# Altium schematic sheet Schematic.SchDoc
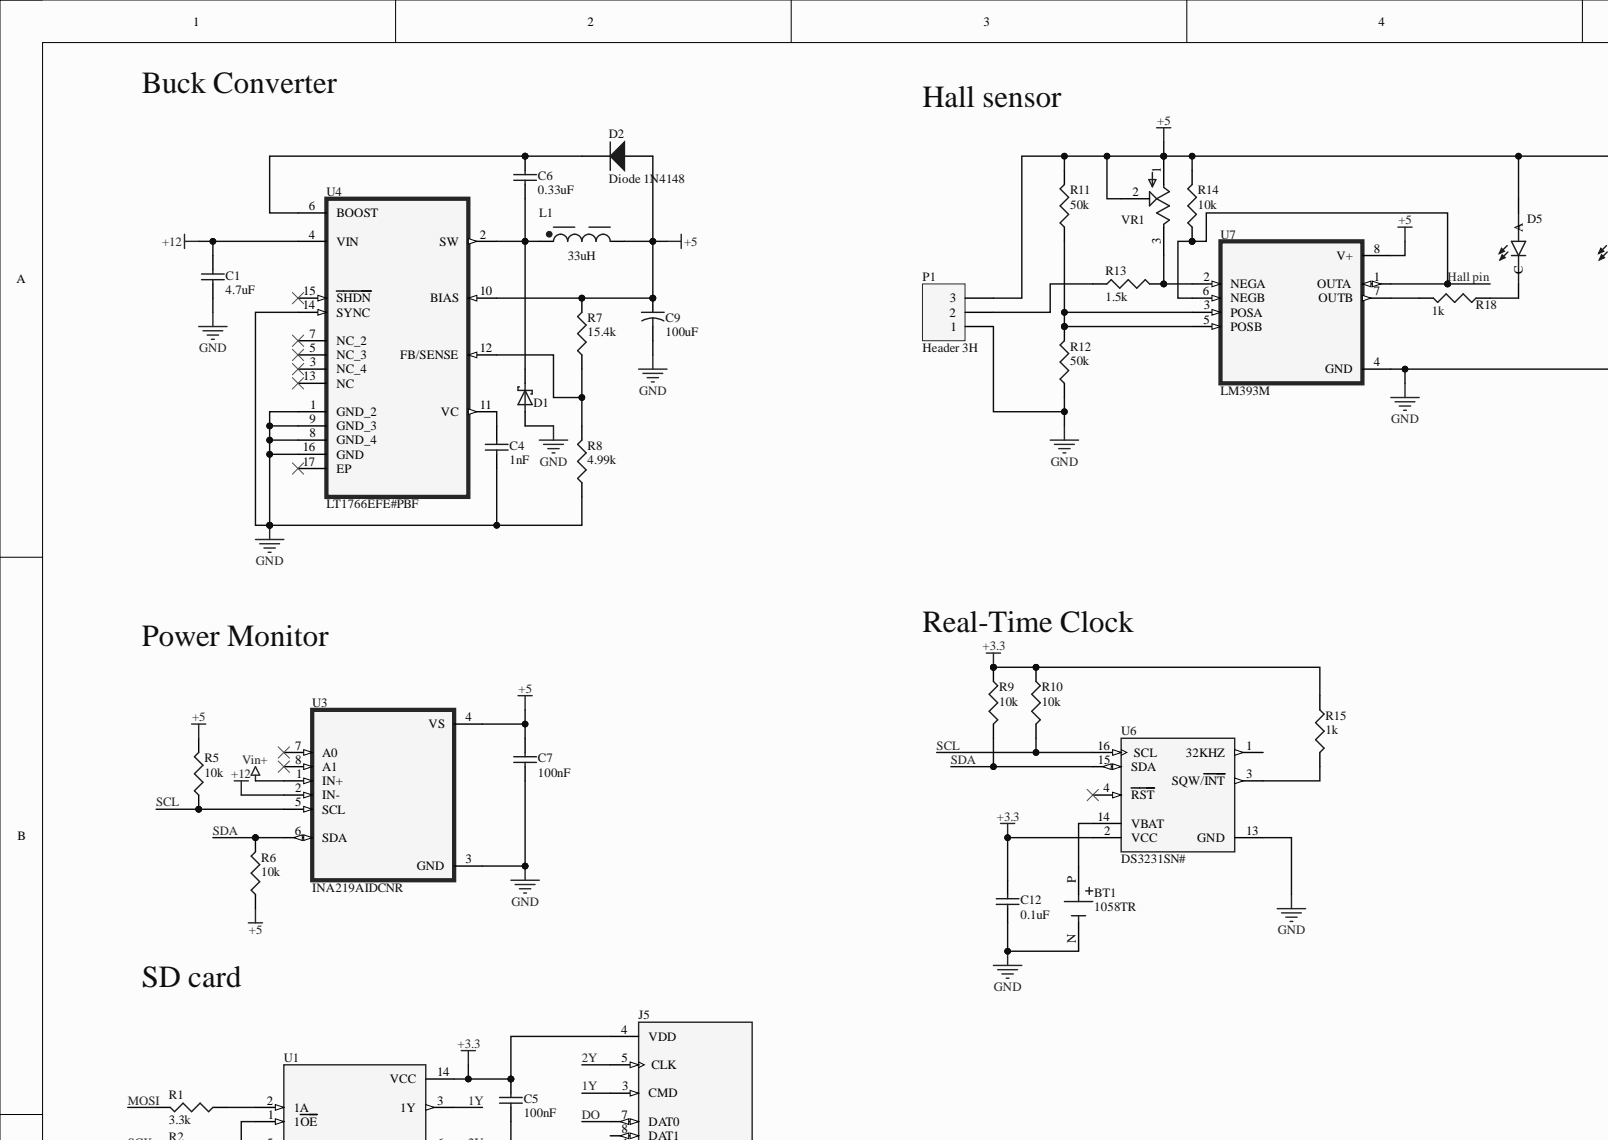
[diagram p0: Schematic.SchDoc, top left]
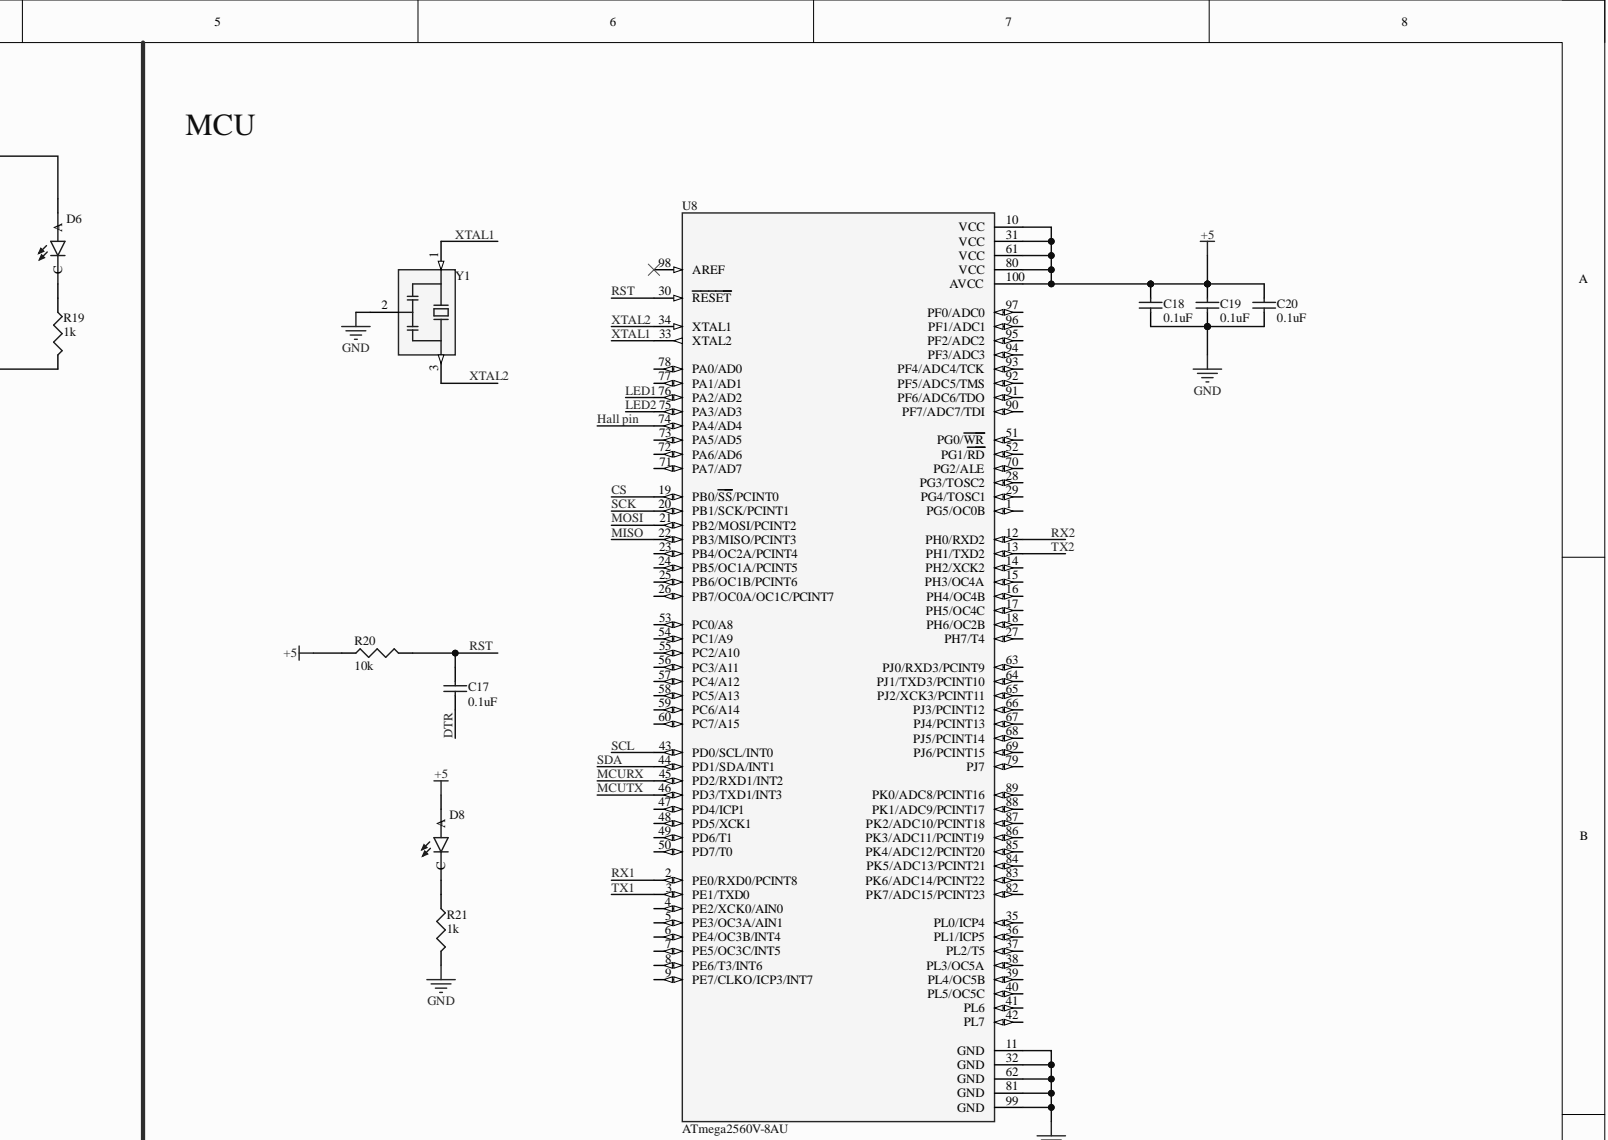
[diagram p1: Schematic.SchDoc, top right]
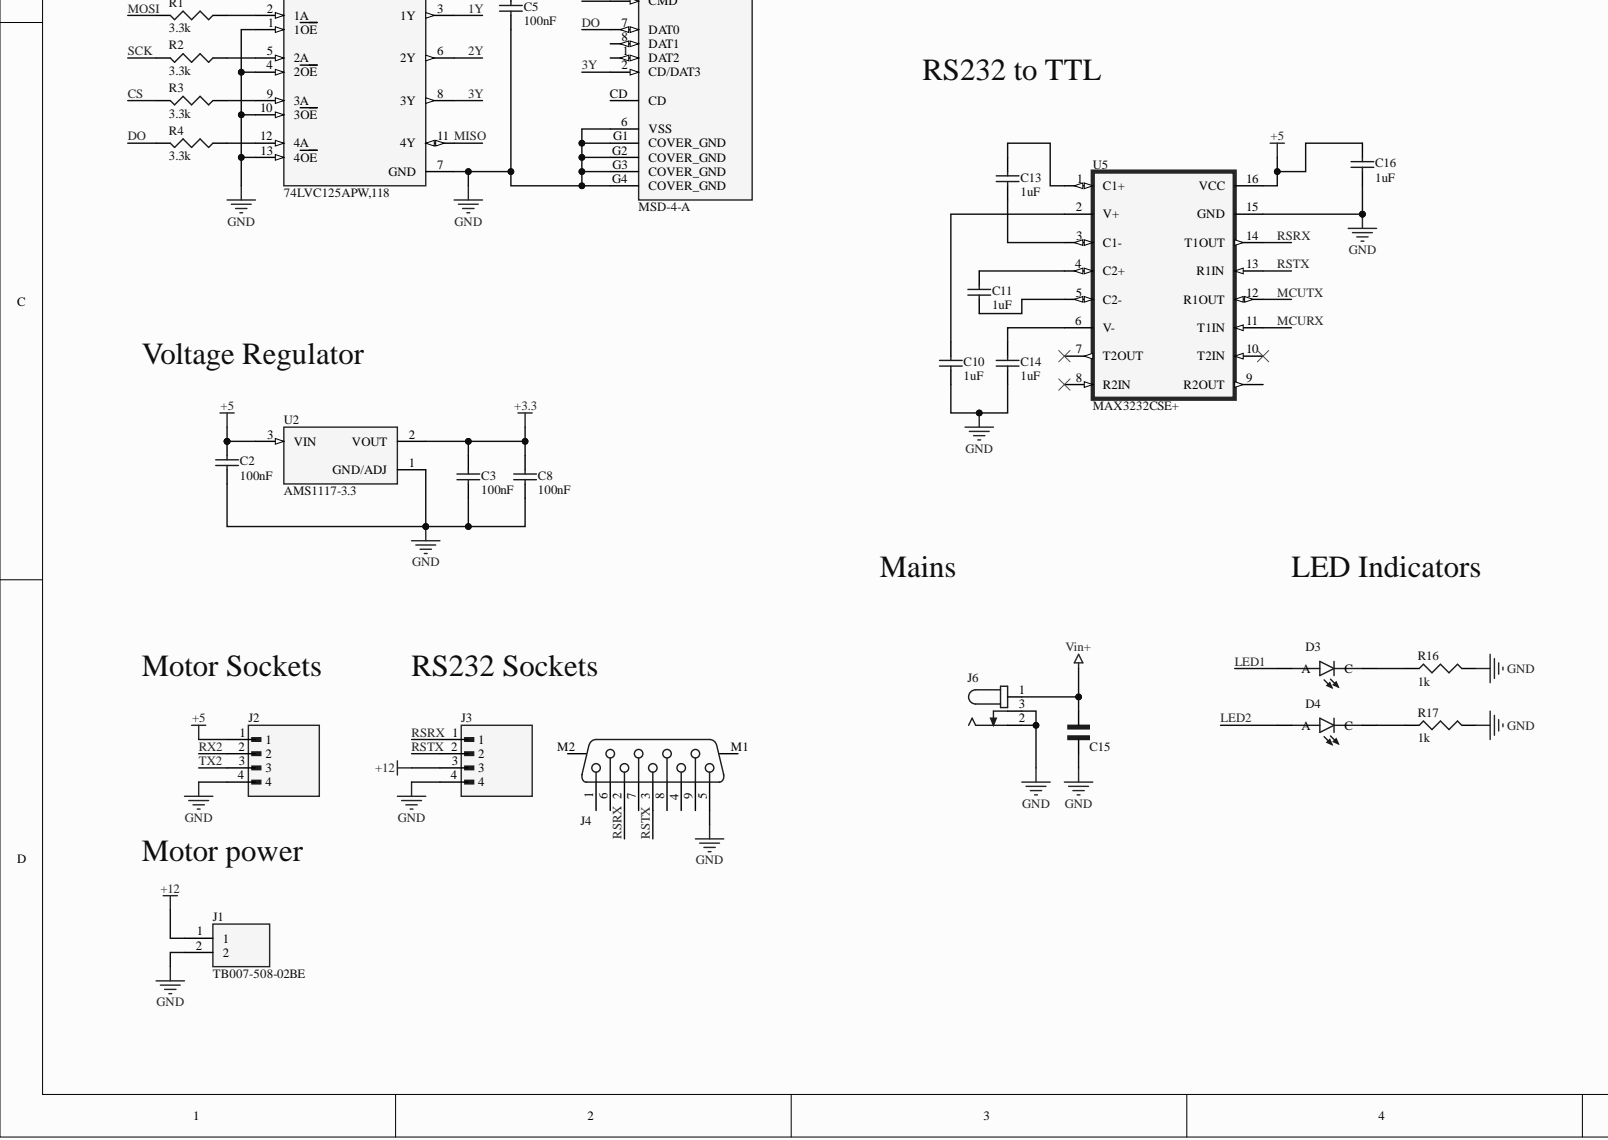
[diagram p2: Schematic.SchDoc, bottom left]
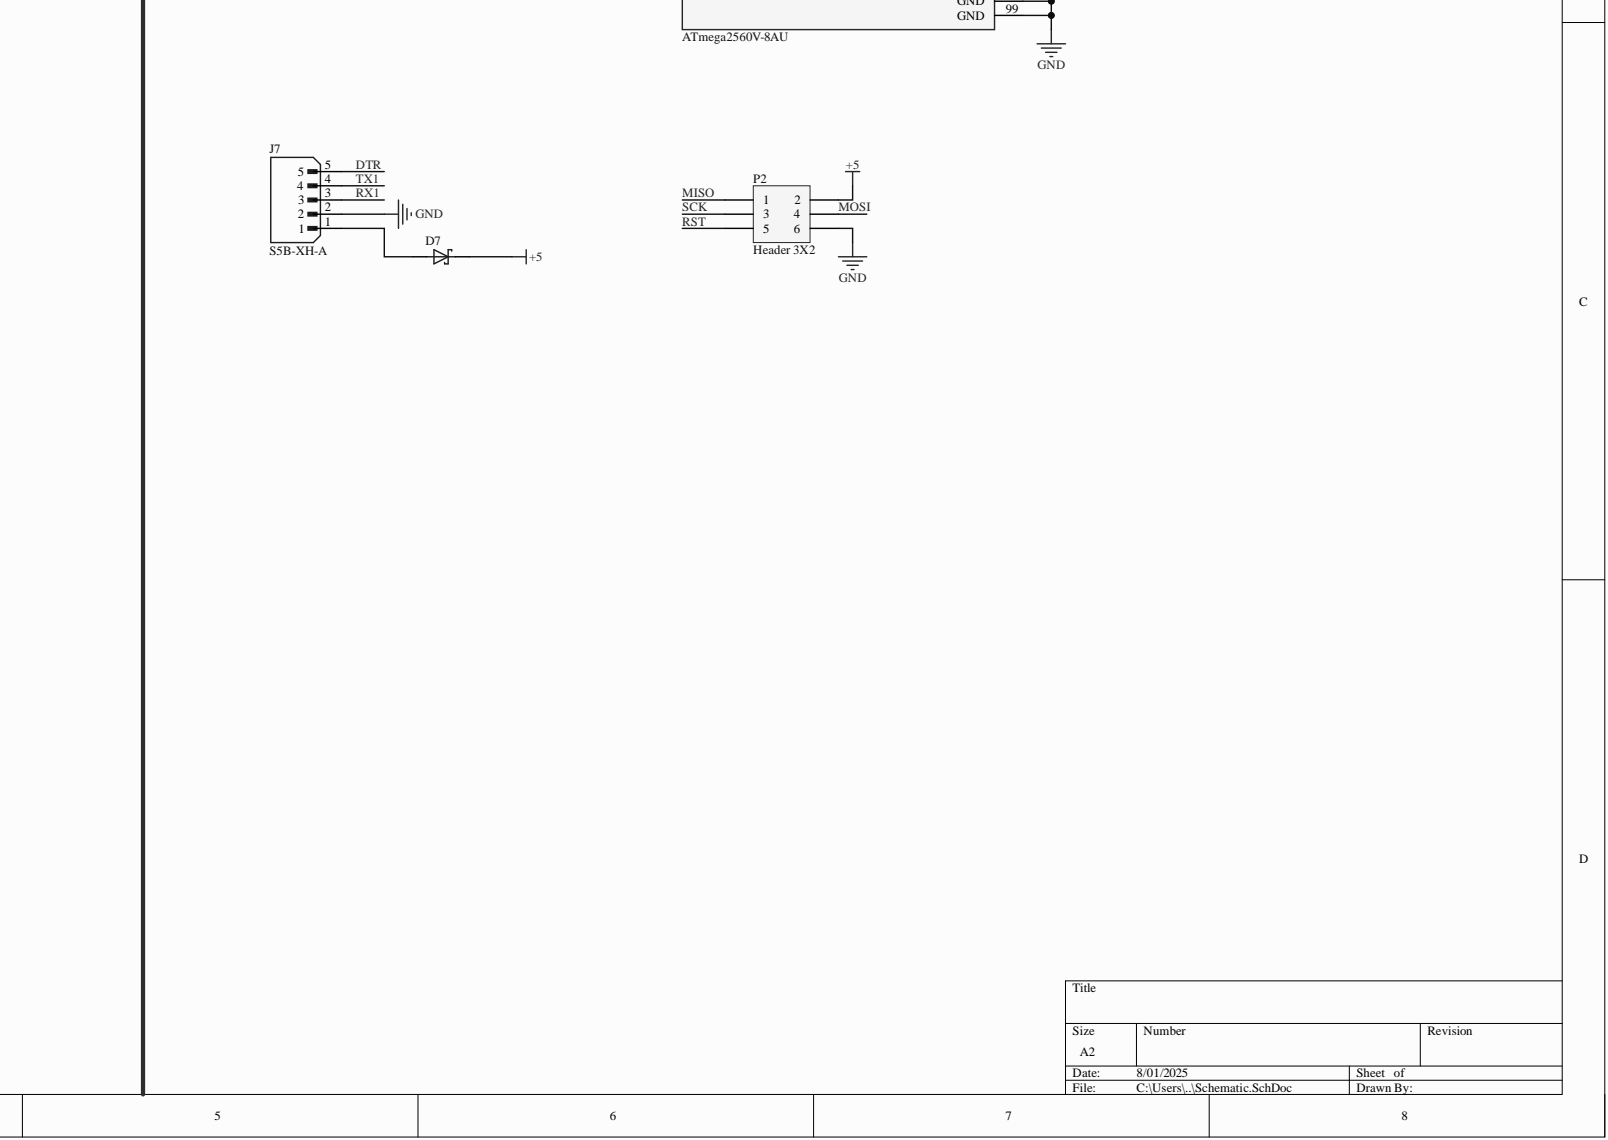
[diagram p3: Schematic.SchDoc, bottom right]

## Parts
BT1  1058TR  [BAT_1058TR]
C1  value 4.7uF  [INDC1608X95]  (hidden comment: Cap Semi)
C2  value 100nF  [INDC1608X95]  (hidden comment: Cap Semi)
C3  value 100nF  [INDC1608X95]  (hidden comment: Cap Semi)
C4  value 1nF  [INDC1608X95]  (hidden comment: Cap Semi)
C5  value 100nF  [INDC1608X95]  (hidden comment: Cap Semi)
C6  value 0.33uF  [INDC1608X95]  (hidden comment: Cap Semi)
C7  value 100nF  [INDC1608X95]  (hidden comment: Cap Semi)
C8  value 100nF  [INDC1608X95]  (hidden comment: Cap Semi)
C9  value 100uF  [CAPR5-4X5]  (hidden comment: Cap2)
C10  value 1uF  [INDC1608X95]  (hidden comment: Cap Semi)
C11  value 1uF  [INDC1608X95]  (hidden comment: Cap Semi)
C12  value 0.1uF  [INDC1608X95]  (hidden comment: Cap Semi)
C13  value 1uF  [INDC1608X95]  (hidden comment: Cap Semi)
C14  value 1uF  [INDC1608X95]  (hidden comment: Cap Semi)
C15  GRM21BC71E106KE11L  [CAPC2012X145N]  (value not drawn)
C16  value 1uF  [INDC1608X95]  (hidden comment: Cap Semi)
C17  value 0.1uF  [INDC1608X95]  (hidden comment: Cap Semi)
C18  value 0.1uF  [INDC1608X95]  (hidden comment: Cap Semi)
C19  value 0.1uF  [INDC1608X95]  (hidden comment: Cap Semi)
C20  value 0.1uF  [INDC1608X95]  (hidden comment: Cap Semi)
D1  B520CQ-13-F  [DIOM5226X240N]  (value not drawn)
D2  Diode 1N4148  [DO-35]
D3  597-3326-607F  [LEDSC160X80X70L45N]  (value not drawn)
D4  597-3326-607F  [LEDSC160X80X70L45N]  (value not drawn)
D5  597-3326-607F  [LEDSC160X80X70L45N]  (value not drawn)
D6  597-3326-607F  [LEDSC160X80X70L45N]  (value not drawn)
D7  B520CQ-13-F  [DIOM5226X240N]  (value not drawn)
D8  597-3326-607F  [LEDSC160X80X70L45N]  (value not drawn)
J1  TB007-508-02BE  [CUI_TB007-508-02BE]
J2  S4B-XH-A(LF)(SN)  [JST_S4B-XH-A(LF)(SN)]  (value not drawn)
J3  S4B-XH-A(LF)(SN)  [JST_S4B-XH-A(LF)(SN)]  (value not drawn)
J4  618009231121  [618009231121]  (value not drawn)
J5  MSD-4-A  [CUI_MSD-4-A]
J6  694103303002  [694103303002]  (value not drawn)
J7  S5B-XH-A  [JST_S5B-XH-A]
L1  33uH  [WE-PD HV_1280]
P1  Header 3H  [HDR1X3H]
P2  Header 3X2  [HDR2X3]
R1  value 3.3k  [J1-0603]  (hidden comment: Res3)
R2  value 3.3k  [J1-0603]  (hidden comment: Res3)
R3  value 3.3k  [J1-0603]  (hidden comment: Res3)
R4  value 3.3k  [J1-0603]  (hidden comment: Res3)
R5  value 10k  [J1-0603]  (hidden comment: Res3)
R6  value 10k  [J1-0603]  (hidden comment: Res3)
R7  value 15.4k  [J1-0603]  (hidden comment: Res3)
R8  value 4.99k  [J1-0603]  (hidden comment: Res3)
R9  value 10k  [J1-0603]  (hidden comment: Res3)
R10  value 10k  [J1-0603]  (hidden comment: Res3)
R11  value 50k  [J1-0603]  (hidden comment: Res3)
R12  value 50k  [J1-0603]  (hidden comment: Res3)
R13  value 1.5k  [J1-0603]  (hidden comment: Res3)
R14  value 10k  [J1-0603]  (hidden comment: Res3)
R15  value 1k  [J1-0603]  (hidden comment: Res3)
R16  value 1k  [J1-0603]  (hidden comment: Res3)
R17  value 1k  [J1-0603]  (hidden comment: Res3)
R18  value 1k  [J1-0603]  (hidden comment: Res3)
R19  value 1k  [J1-0603]  (hidden comment: Res3)
R20  value 10k  [J1-0603]  (hidden comment: Res3)
R21  value 1k  [J1-0603]  (hidden comment: Res3)
U1  74LVC125APW,118  [IC_74LVC125APW,118]
U2  AMS1117-3.3  [SOT229P700X180-4N]
U3  INA219AIDCNR  [SOT65P280X145-8N]
U4  LT1766EFE#PBF  [SOP65P640X110-17N]
U5  MAX3232CSE+  [SOIC127P600X175-16N]
U6  DS3231SN#  [SOIC127P1032X265-16N]
U7  LM393M  [SOIC127P599X175-8N]
U8  ATmega2560V-8AU  [QFP50P1600X1600X120-100N]
VR1  3362P-1-104  [TRIM_3362P-1-104]  (value not drawn)
Y1  CSTCE16M0V53-R0  [OSC_CSTCE16M0V53-R0]  (value not drawn)

## Nets
+3.3: C3.2 C5.2 C8.2 C12.2 J5.4(VDD) R9.1 R10.1 R15.1 U1.14(VCC) U2.2 U6.2(VCC)
+5: C2.2 C7.2 C9.1 C16.2 C18.1 C19.1 C20.1 D2.1 D5.A D6.A D7.C D8.A J2.1 L1.2 P1.3 P2.2 R5.2 R6.1 R7.2 R11.2 R14.2 R20.1 U2.3 U3.4(VS) U4.10(BIAS) U5.16(VCC) U7.8(V+) U8.10(VCC) U8.31(VCC) U8.61(VCC) U8.80(VCC) U8.100(AVCC) VR1.1 VR1.2
+12: C1.2 J1.1 J3.3 U3.2(IN-) U4.4(VIN)
GND: BT1.N C1.1 C2.1 C3.1 C4.1 C5.1 C7.1 C8.1 C9.2 C10.1 C12.1 C14.1 C15.1 C16.1 C18.2 C19.2 C20.2 D1.A J1.2 J2.4 J3.4 J4.5 J5.6(VSS) J5.G1(COVER_GND) J5.G2(COVER_GND) J5.G3(COVER_GND) J5.G4(COVER_GND) J6.2 J6.3 J7.2 P1.1 P2.6 R8.1 R12.1 R16.2 R17.2 R19.2 R21.2 U1.1(1OE) U1.4(2OE) U1.7(GND) U1.10(3OE) U1.13(4OE) U2.1 U3.3(GND) U4.1(GND_2) U4.8(GND_4) U4.9(GND_3) U4.14(SYNC) U4.16(GND) U5.15(GND) U6.13(GND) U7.4(GND) U8.11(GND) U8.32(GND) U8.62(GND) U8.81(GND) U8.99(GND) Y1.2
Vin+: C15.2 J6.1 U3.1(IN+)
1Y: J5.3(CMD) U1.3(1Y)
2Y: J5.5(CLK) U1.6(2Y)
3Y: J5.2(CD/DAT3) U1.8(3Y)
CS: R3.2 U8.19(PB0/SS/PCINT0)
DO: J5.7(DAT0) R4.2
DTR: C17.2 J7.5
Hall pin: R14.1 U7.1(OUTA) U7.6(NEGB) U8.74(PA4/AD4)
LED1: D3.A U8.76(PA2/AD2)
LED2: D4.A U8.75(PA3/AD3)
MCURX: U5.11(T1IN) U8.45(PD2/RXD1/INT2)
MCUTX: U5.12(R1OUT) U8.46(PD3/TXD1/INT3)
MISO: P2.1 U1.11(4Y) U8.22(PB3/MISO/PCINT3)
MOSI: P2.4 R1.2 U8.21(PB2/MOSI/PCINT2)
RSRX: J3.1 J4.2 U5.14(T1OUT)
RST: C17.1 P2.5 R20.2 U8.30(RESET)
RSTX: J3.2 J4.3 U5.13(R1IN)
RX1: J7.3 U8.2(PE0/RXD0/PCINT8)
RX2: J2.2 U8.12(PH0/RXD2)
SCK: P2.3 R2.2 U8.20(PB1/SCK/PCINT1)
SCL: R5.1 R10.2 U3.5(SCL) U6.16(SCL) U8.43(PD0/SCL/INT0)
SDA: R6.2 R9.2 U3.6(SDA) U6.15(SDA) U8.44(PD1/SDA/INT1)
TX1: J7.4 U8.3(PE1/TXD0)
TX2: J2.3 U8.13(PH1/TXD2)
XTAL1: U8.33(XTAL2) Y1.1
XTAL2: U8.34(XTAL1) Y1.3
NetBT1_P: BT1.P U6.14(VBAT)
NetC4_2: C4.2 U4.11(VC)
NetC6_1: C6.1 D1.C L1.1 U4.2(SW)
NetC6_2: C6.2 D2.2 U4.6(BOOST)
NetC10_2: C10.2 U5.2(V+)
NetC11_1: C11.1 U5.5(C2-)
NetC11_2: C11.2 U5.4(C2+)
NetC13_1: C13.1 U5.3(C1-)
NetC13_2: C13.2 U5.1(C1+)
NetC14_2: C14.2 U5.6(V-)
NetD3_C: D3.C R16.1
NetD4_C: D4.C R17.1
NetD5_C: D5.C R18.1
NetD6_C: D6.C R19.1
NetD7_A: D7.A J7.1
NetD8_C: D8.C R21.1
NetP1_2: P1.2 R13.1
NetR1_1: R1.1 U1.2(1A)
NetR2_1: R2.1 U1.5(2A)
NetR3_1: R3.1 U1.9(3A)
NetR4_1: R4.1 U1.12(4A)
NetR7_1: R7.1 R8.2 U4.12(FB/SENSE)
NetR11_1: R11.1 R12.2 U7.3(POSA) U7.5(POSB)
NetR13_2: R13.2 U7.2(NEGA) VR1.3
NetR15_2: R15.2 U6.3(SQW/INT)
NetR18_2: R18.2 U7.7(OUTB)

Not connected: J4 1 4 6 7 8 9 M1 M2; J5 1(DAT2) 8(DAT1) CD; U3 7(A0) 8(A1); U4 3(NC_4) 5(NC_3) 7(NC_2) 13(NC) 15(SHDN) 17(EP); U5 7(T2OUT) 8(R2IN) 9(R2OUT) 10(T2IN); U6 1(32KHZ) 4(RST); U8 1(PG5/OC0B) 4(PE2/XCK0/AIN0) 5(PE3/OC3A/AIN1) 6(PE4/OC3B/INT4) 7(PE5/OC3C/INT5) 8(PE6/T3/INT6) 9(PE7/CLKO/ICP3/INT7) 14(PH2/XCK2) 15(PH3/OC4A) 16(PH4/OC4B) 17(PH5/OC4C) 18(PH6/OC2B) 23(PB4/OC2A/PCINT4) 24(PB5/OC1A/PCINT5) 25(PB6/OC1B/PCINT6) 26(PB7/OC0A/OC1C/PCINT7) 27(PH7/T4) 28(PG3/TOSC2) 29(PG4/TOSC1) 35(PL0/ICP4) 36(PL1/ICP5) 37(PL2/T5) 38(PL3/OC5A) 39(PL4/OC5B) 40(PL5/OC5C) 41(PL6) 42(PL7) 47(PD4/ICP1) 48(PD5/XCK1) 49(PD6/T1) 50(PD7/T0) 51(PG0/WR) 52(PG1/RD) 53(PC0/A8) 54(PC1/A9) 55(PC2/A10) 56(PC3/A11) 57(PC4/A12) 58(PC5/A13) 59(PC6/A14) 60(PC7/A15) 63(PJ0/RXD3/PCINT9) 64(PJ1/TXD3/PCINT10) 65(PJ2/XCK3/PCINT11) 66(PJ3/PCINT12) 67(PJ4/PCINT13) 68(PJ5/PCINT14) 69(PJ6/PCINT15) 70(PG2/ALE) 71(PA7/AD7) 72(PA6/AD6) 73(PA5/AD5) 77(PA1/AD1) 78(PA0/AD0) 79(PJ7) 82(PK7/ADC15/PCINT23) 83(PK6/ADC14/PCINT22) 84(PK5/ADC13/PCINT21) 85(PK4/ADC12/PCINT20) 86(PK3/ADC11/PCINT19) 87(PK2/ADC10/PCINT18) 88(PK1/ADC9/PCINT17) 89(PK0/ADC8/PCINT16) 90(PF7/ADC7/TDI) 91(PF6/ADC6/TDO) 92(PF5/ADC5/TMS) 93(PF4/ADC4/TCK) 94(PF3/ADC3) 95(PF2/ADC2) 96(PF1/ADC1) 97(PF0/ADC0) 98(AREF)

## Library data of the parts
BT1 = 1058TR: SMT (Auto-In) Holder for 2025 & 2032 Cell on Tape & Reel | Keystone Electronics 1058TR; Check_prices: https://www.snapeda.com/parts/1058TR/Keystone/view-part/?ref=eda; Component Kind: Standard; Library Name: 1058TR.IntLib; MF: Keystone Electronics; MP: 1058TR; Package: None; SnapEDA_Link: https://www.snapeda.com/parts/1058TR/Keystone/view-part/?ref=snap
C1-C8 C10-C14 C16-C20 = Cap Semi: Capacitor (Semiconductor SIM Model); Component Kind: Standard; LatestRevisionDate: 29-May-2009; LatestRevisionNote: IPC-7351 Footprint Added.; Library Name: Miscellaneous Devices.IntLib; PackageDescription: Chip Capacitor; PackageReference: C1206; Published: 8-Jun-2000; Signal Integrity: Cap Semi; Simulation: CAP; Publisher: Altium Limited
C9 = Cap2: Capacitor; Component Kind: Standard; Library Name: Miscellaneous Devices.IntLib; PackageDescription: Capacitor; 2 Leads; PackageReference: CAPR5-4X5; Published: 23-Sep-2002; Signal Integrity: Cap; Simulation: CAP; Publisher: Altium Limited
C15 = GRM21BC71E106KE11L: SMD Capacitor X7S(EIA) with Capacitance: 10uF Tol. 10%. Rated Voltage: 25Vdc; MF: Murata; Check_prices: https://www.snapeda.com/parts/GRM21BC71E106KE11L/Murata/view-part/?ref=eda; Component Kind: Standard; Library Name: GRM21BC71E106KE11L.IntLib; MP: GRM21BC71E106KE11L; Package: 2012 Murata; SnapEDA_Link: https://www.snapeda.com/parts/GRM21BC71E106KE11L/Murata/view-part/?ref=snap
D1 D7 = B520CQ-13-F: Diode Schottky 20 V 5A Surface Mount SMC; MF: Diodes Inc.; Check_prices: https://www.snapeda.com/parts/B520CQ-13-F/Diodes+Inc./view-part/?ref=eda; Component Kind: Standard; Library Name: B520CQ-13-F.IntLib; MP: B520CQ-13-F; Package: SMC-2 Diodes; SnapEDA_Link: https://www.snapeda.com/parts/B520CQ-13-F/Diodes+Inc./view-part/?ref=snap
D2 = Diode 1N4148: High Conductance Fast Diode; Code_JEDEC: DO-204-AH; Component Kind: Standard; ComponentLink1Description: Manufacturer Link; ComponentLink1URL: http://www.fairchildsemi.com/; ComponentLink2Description: Datasheet; ComponentLink2URL: http://www.fairchildsemi.com/ds/1N%2F1N4148.pdf; DatasheetVersion: 1997; LatestRevisionDate: 17-Jul-2002; LatestRevisionNote: Re-released for DXP Platform.; Library Name: Miscellaneous Devices.IntLib; PackageDescription: Diode, Axial; Body 3.8 x 1.9 mm (LxDia. max), Lead Dia. 0.53 mm (max); PackageReference: DO-35; Published: 8-Jun-2000; Signal Integrity: 1N4148; Simulation: 1N4148; Publisher: Altium Limited
D3-D6 D8 = 597-3326-607F: SMD LED 0603 INGAN GREEN | Dialight 597-3326-607F; Check_prices: https://www.snapeda.com/parts/597-3326-607F/Dialight/view-part/?ref=eda; Component Kind: Standard; Library Name: 597-3326-607F.IntLib; MF: Dialight; MP: 597-3326-607F; Package: 0603 Dialight; SnapEDA_Link: https://www.snapeda.com/parts/597-3326-607F/Dialight/view-part/?ref=snap
J1 = TB007-508-02BE: 2~24 Poles, Screw Type, Horizontal, 5.08 Pitch, 24~12 (AWG), Terminal Block Connector; MF: Same Sky; Check_prices: https://www.snapeda.com/parts/TB007-508-02BE/Same+Sky/view-part/?ref=eda; Component Kind: Standard; Library Name: TB007-508-02BE.IntLib; MP: TB007-508-02BE; Package: None; SnapEDA_Link: https://www.snapeda.com/parts/TB007-508-02BE/Same+Sky/view-part/?ref=snap
J4 = 618009231121: WR-DSUB 8.08mm Female PCB Connector with Hex Screw, Angled, 9p; Manufacturer: Wurth Elektronik; Contact Resistance: 30mOhm; Rated Current: 3A; Withstanding Voltage: 1000VAC; Working Voltage: 125VAC; Category: Input/Output Connectors; Component Kind: Standard; ComponentLink1Description: Website Link; ComponentLink1URL: https://www.we-online.com/redexpert/article/618009231121?ad; ComponentLink2Description: Datasheet Link; ComponentLink2URL: https://www.we-online.com/redexpert/spec/618009231121?ad; Gender: Female; Library Name: Altium_WR-DSUB+(rev23a).IntLib; Manufacturer Part Number: 618009231121; Match Code: WR-DSUB; Mount: Through Hole; Number of Pins: 9; Operating Temperature Max: 105°C; Operating Temperature Min: -55°C; Orientation: Angled; Packaging: Tray
J5 = MSD-4-A: Micro SD Card Connector, 9 Positions, Connector and Ejector, Push In, Auto Eject Out, Surface Mount, 1.8 mm Height Above Board, Gold Flash; MF: Same Sky; Check_prices: https://www.snapeda.com/parts/MSD-4-A/Same+Sky/view-part/?ref=eda; Component Kind: Standard; Library Name: MSD-4-A.IntLib; MP: MSD-4-A; Package: None; SnapEDA_Link: https://www.snapeda.com/parts/MSD-4-A/Same+Sky/view-part/?ref=snap
J6 = 694103303002: WR-DC Series, 3.5mm Right Angle Through Hole DC Power Socket 2A | Wurth Electronics 694103303002; MF: Wurth Elektronik; Check_prices: https://www.snapeda.com/parts/694103303002/Wurth+Electronics/view-part/?ref=eda; Component Kind: Standard; Library Name: 694103303002.IntLib; MP: 694103303002; Package: None; SnapEDA_Link: https://www.snapeda.com/parts/694103303002/Wurth+Electronics/view-part/?ref=snap
J7 = S5B-XH-A: Connector Header Through Hole, Right Angle 5 position 0.098 (2.50mm); MF: JST Sales; Check_prices: https://www.snapeda.com/parts/S5B-XH-A/JST+Sales+America+Inc./view-part/?ref=eda; Component Kind: Standard; Library Name: S5B-XH-A.IntLib; MP: S5B-XH-A; Package: None; SnapEDA_Link: https://www.snapeda.com/parts/S5B-XH-A/JST+Sales+America+Inc./view-part/?ref=snap
L1 = 768770331: WE-PD HV High Voltage Power Inductor, SMT, size 1280, 330µH, 1A, 0.65Ω; Manufacturer: Wurth Elektronik; Case/Size Code: 1280; Operating Voltage (DC): 400V; Saturation Current: 1.2A; Self Resonant Frequency: 3MHz; Category: Power Inductors; Component Kind: Standard; ComponentLink1Description: Website Link; ComponentLink1URL: https://www.we-online.com/redexpert/article/768770331?ad; ComponentLink2Description: Datasheet Link; ComponentLink2URL: https://www.we-online.com/redexpert/spec/768770331?ad; DC Resistance Max: 0.65Ω; Height: 8.5mm; Inductance: 330µH; Length: 12mm; Manufacturer Part Number: 768770331; Match Code: WE-PD HV; Max Operating Temperature: 125°C; Min Operating Temperature: -40°C; Mount: Surface Mount; Packaging: Tape and Reel; Rated Current: 1A; Width: 12mm; Library Name: WE-PDHV+(rev25a).IntLib
P1 = Header 3H: Header, 3-Pin, Right Angle; Component Kind: Standard; LatestRevisionDate: 17-Jul-2002; LatestRevisionNote: Re-released for DXP Platform.; Library Name: Miscellaneous Connectors.IntLib; Signal Integrity: Connector; Publisher: Altium Limited
P2 = Header 3X2: Header, 3-Pin, Dual row; Component Kind: Standard; LatestRevisionDate: 17-Jul-2002; LatestRevisionNote: Re-released for DXP Platform.; Library Name: Miscellaneous Connectors.IntLib; Signal Integrity: Connector; Publisher: Altium Limited
R1-R21 = Res3: Resistor; Component Kind: Standard; LatestRevisionDate: 29-May-2009; LatestRevisionNote: IPC-7351 Footprint Added.; Library Name: Miscellaneous Devices.IntLib; PackageDescription: Chip Resistor; PackageReference: J1-0603; Published: 8-Jun-2000; Signal Integrity: Res; Simulation: RESISTOR; Publisher: Altium Limited
U1 = 74LVC125APW,118: Buffer, Non-Inverting 4 Element 1 Bit per Element 3-State Output 14-TSSOP; MF: Nexperia USA Inc.; Check_prices: https://www.snapeda.com/parts/74LVC125APW,118/Nexperia/view-part/?ref=eda; Component Kind: Standard; Digi-Key_Part_Number: 1727-3092-2-ND; Library Name: 74LVC125APW_118.IntLib; MP: 74LVC125APW,118; Package: TSSOP14-14 Nexperia USA Inc.; Purchase-URL: https://pricing.snapeda.com/search/part/74LVC125APW,118/?ref=eda; SnapEDA_Link: https://www.snapeda.com/parts/74LVC125APW,118/Nexperia/view-part/?ref=snap
U2 = AMS1117-3.3: Sot223/Pkg 1-Amp 3.3-Volt Low Drop Out Voltage Regulatator; MF: Advanced Monolithic Systems; Check_prices: https://www.snapeda.com/parts/AMS1117-3.3/Advanced+Monolithic+Systems/view-part/?ref=eda; Component Kind: Standard; Library Name: AMS1117-3.3.IntLib; MP: AMS1117-3.3; Package: SOT-223 Seeed Technology; SnapEDA_Link: https://www.snapeda.com/parts/AMS1117-3.3/Advanced+Monolithic+Systems/view-part/?ref=snap
U3 = INA219AIDCNR: 26-V 12-bit I2C output digital power monitor; MF: Texas Instruments; Check_prices: https://www.snapeda.com/parts/INA219AIDCNR/Texas+Instruments/view-part/?ref=eda; Component Kind: Standard; Library Name: INA219AIDCNR.IntLib; MP: INA219AIDCNR; Package: SOT-23-8 Texas Instruments; SnapEDA_Link: https://www.snapeda.com/parts/INA219AIDCNR/Texas+Instruments/view-part/?ref=snap
U4 = LT1766EFE#PBF: 5.5V to 60V 1.5A, 200kHz Step-Down Switching Regulator; MF: Analog Devices; Check_prices: https://www.snapeda.com/parts/LT1766EFE%23PBF/Analog+Devices/view-part/?ref=eda; Component Kind: Standard; MP: LT1766EFE#PBF; Package: TSSOP -16 Analog Devices; SnapEDA_Link: https://www.snapeda.com/parts/LT1766EFE%23PBF/Analog+Devices/view-part/?ref=snap; Library Name: Feeder system v2.SCHLIB
U5 = MAX3232CSE+: 3.0V to 5.5V, Low-Power, Up to 1Mbps, True RS-232 Transceivers; MF: Analog Devices; Check_prices: https://www.snapeda.com/parts/MAX3232CSE+/Analog+Devices/view-part/?ref=eda; Component Kind: Standard; MP: MAX3232CSE+; Package: SOIC-16 Maxim Integrated; SnapEDA_Link: https://www.snapeda.com/parts/MAX3232CSE+/Analog+Devices/view-part/?ref=snap; Library Name: Feeder system.SCHLIB
U6 = DS3231SN#: Real Time Clock (RTC) IC Clock/Calendar IÂ²C, 2-Wire Serial 16-SOIC (0.295, 7.50mm Width); MF: Maxim Integrated Products; Availability: In Stock; Check_prices: https://www.snapeda.com/parts/DS3231SN%23/Maxim+Integrated/view-part/?ref=eda; Component Kind: Standard; MP: DS3231SN#; Package: None; Price: None; Purchase-URL: https://pricing.snapeda.com/search/part/DS3231SN#/?ref=eda; SnapEDA_Link: https://www.snapeda.com/parts/DS3231SN%23/Maxim+Integrated/view-part/?ref=snap; Library Name: Feeder system_1.SCHLIB
U7 = LM393M: Low Power Low Offset Voltage Dual Comparator; MF: Texas Instruments; Check_prices: https://www.snapeda.com/parts/LM393M/Texas+Instruments/view-part/?ref=eda; Component Kind: Standard; Library Name: LM393M.IntLib; MP: LM393M; Package: SOIC-8 Texas Instruments; SnapEDA_Link: https://www.snapeda.com/parts/LM393M/Texas+Instruments/view-part/?ref=snap
U8 = ATmega2560V-8AU: AVR AVRÂ® ATmega Microcontroller IC 8-Bit 8MHz 256KB (128K x 16) FLASH 100-TQFP (14x14); MF: Microchip Technology / Atmel; Availability: In Stock; Check_prices: https://www.snapeda.com/parts/ATMEGA2560V-8AU/Atmel/view-part/?ref=eda; Component Kind: Standard; Library Name: ATmega2560V-8AU.IntLib; MP: ATMEGA2560V-8AU; Package: TQFP-100 Microchip; Price: None; Purchase-URL: https://pricing.snapeda.com/search/part/ATMEGA2560V-8AU/?ref=eda; SnapEDA_Link: https://www.snapeda.com/parts/ATMEGA2560V-8AU/Atmel/view-part/?ref=snap
VR1 = 3362P-1-104: TRIMMER - 1/4in SQ ST CERMET | Bourns 3362P-1-104; Check_prices: https://www.snapeda.com/parts/3362P-1-104/Bourns/view-part/?ref=eda; Component Kind: Standard; MF: Bourns; MP: 3362P-1-104; Package: 3362P-1 Bourns Inc.; SnapEDA_Link: https://www.snapeda.com/parts/3362P-1-104/Bourns/view-part/?ref=snap; Library Name: Feeder system_1.SCHLIB
Y1 = CSTCE16M0V53-R0: 16 MHz Ceramic Resonator Built in Capacitor 15 pF Â±0.3% -20Â°C ~ 80Â°C Surface Mount; MF: Murata; MP: CSTCE16M0V53-R0-; Check_prices: https://www.snapeda.com/parts/CSTCE16M0V53-R0-/Murata/view-part/?ref=eda; Component Kind: Standard; Library Name: CSTCE16M0V53-R0.IntLib; Package: None; SnapEDA_Link: https://www.snapeda.com/parts/CSTCE16M0V53-R0-/Murata/view-part/?ref=snap
J2 J3 = S4B-XH-A(LF)(SN): Availability: Unavailable; Component Kind: Standard; Library Name: S4B-XH-A_LF__SN_.IntLib; MP: S4B-XH-A(LF)(SN); Package: None; Price: None
